# Altium schematic sheet Demo_BLDC_1000W_36V.SchDoc
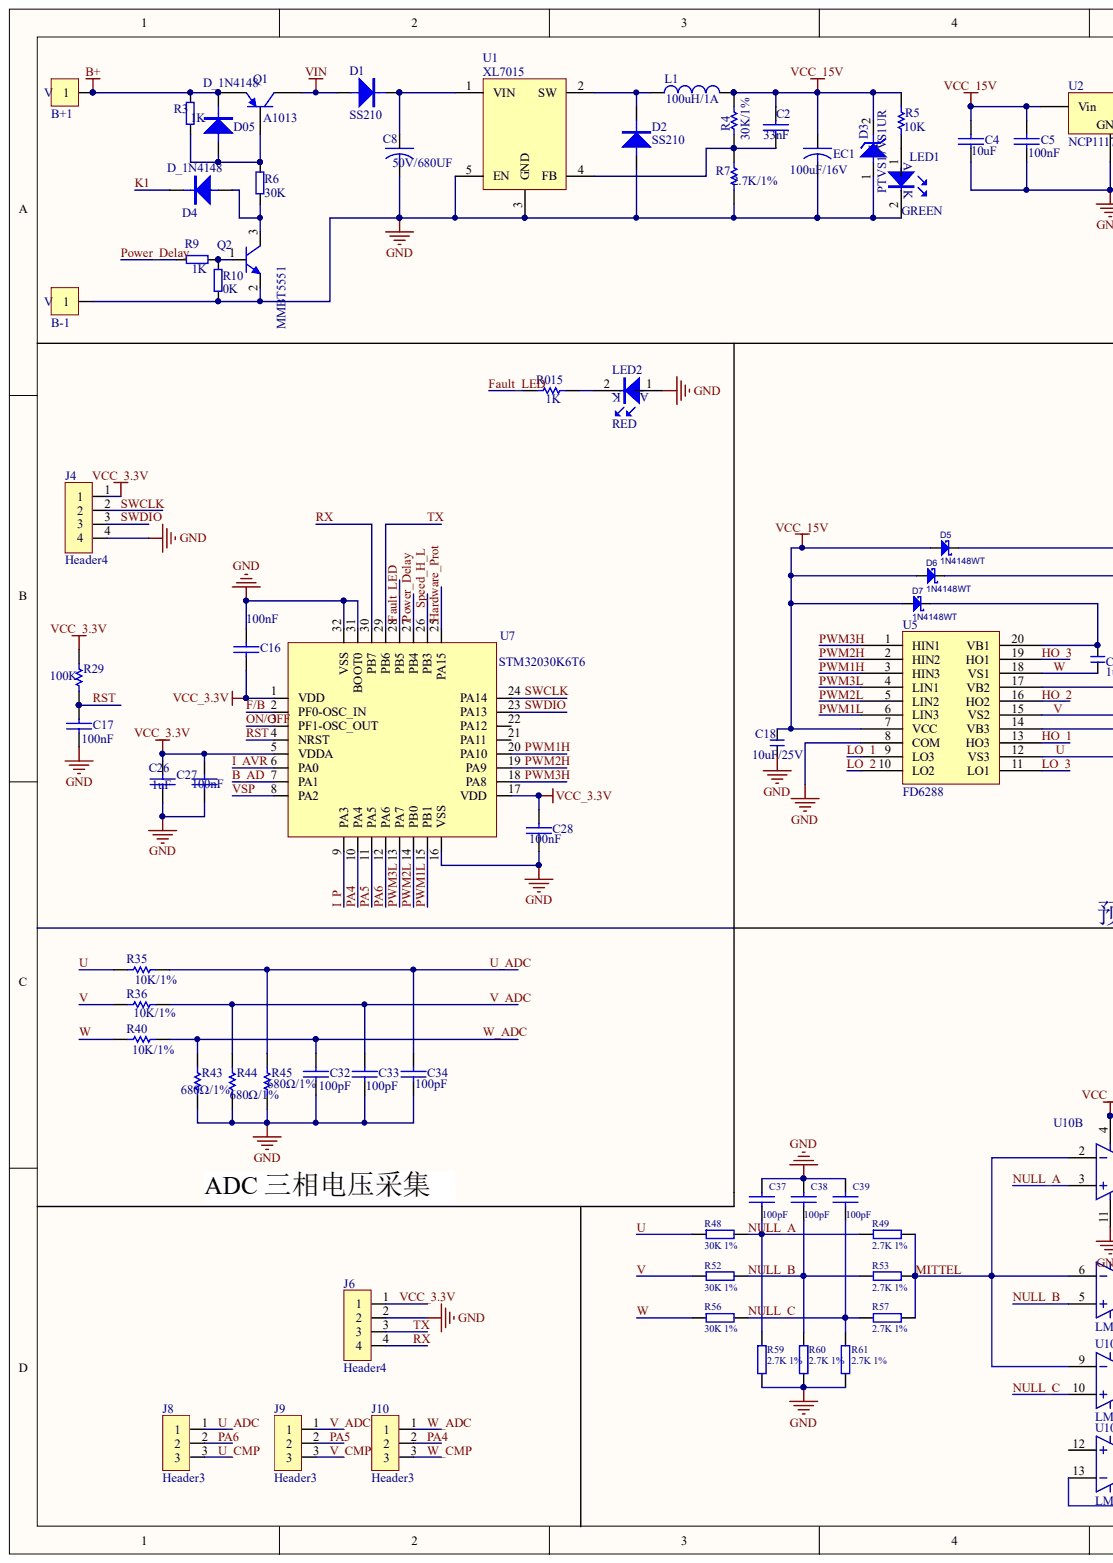
[diagram p0: Demo_BLDC_1000W_36V.SchDoc, left]
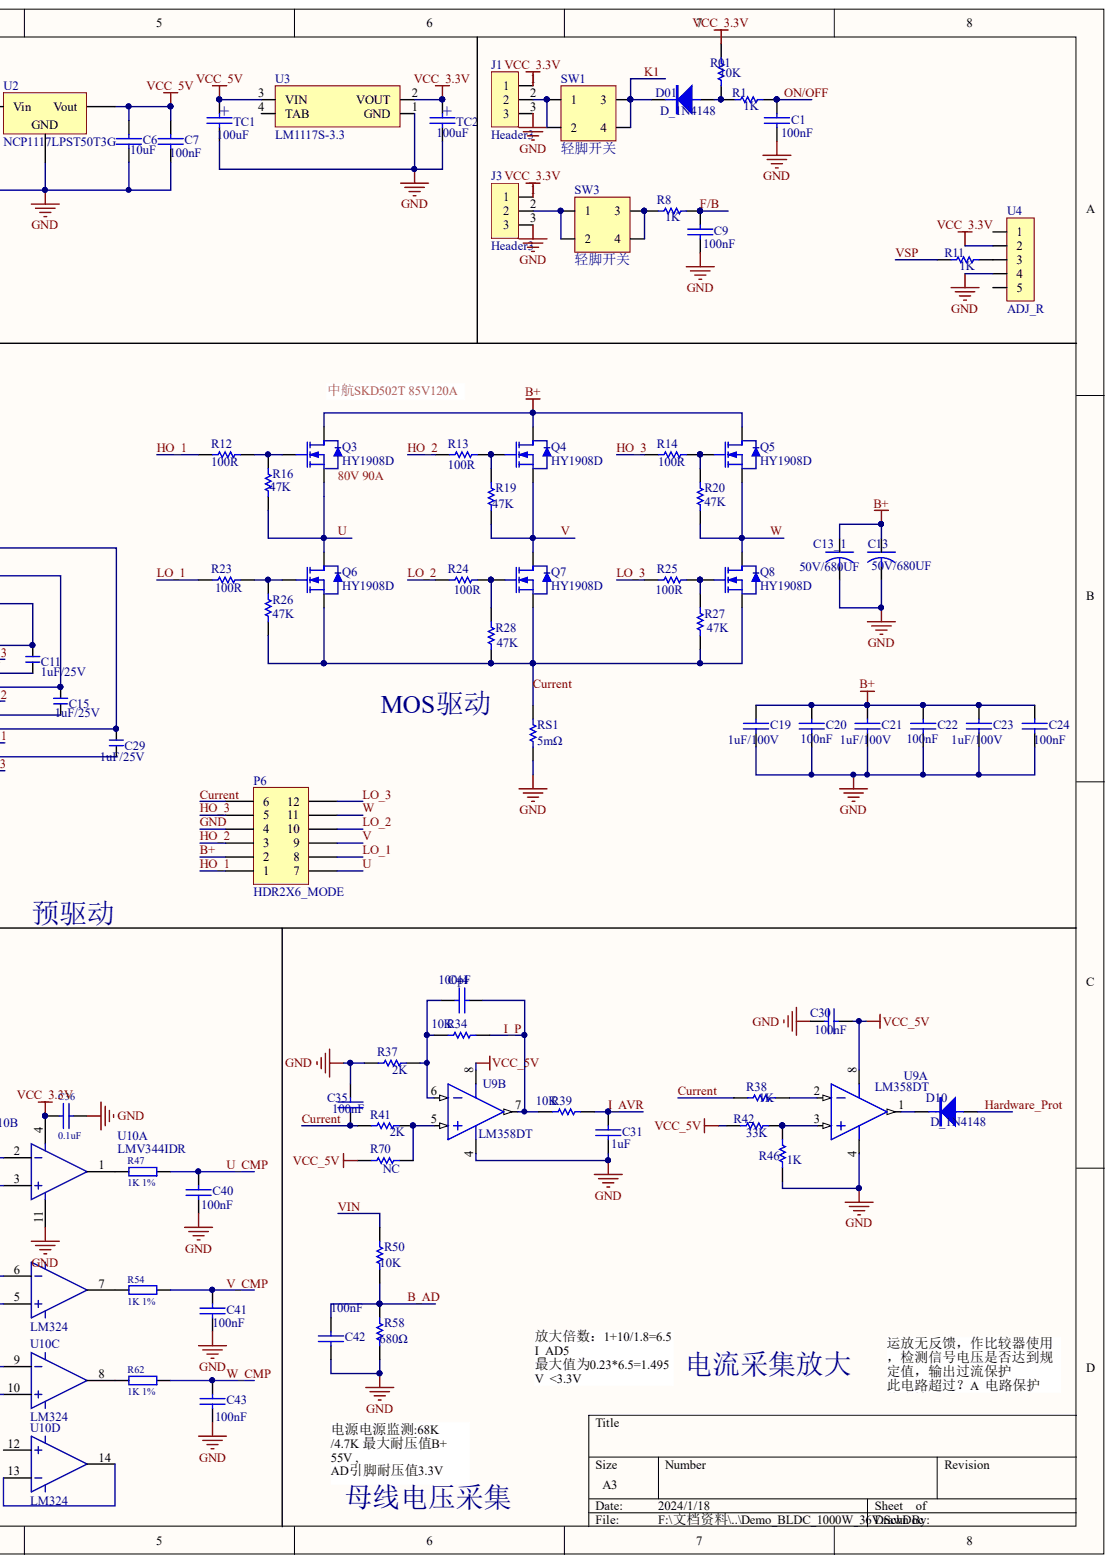
[diagram p1: Demo_BLDC_1000W_36V.SchDoc, right]

## Parts
B+1  V  [TP]
B-1  V  [TP]
C1  100nF  [C0603]
C2  33nF  [C0603]
C4  10uF  [C0805]
C5  100nF  [C0603]
C6  10uF  [C0805]
C7  100nF  [C0603]
C8  50V/680UF  [CAP-SMD_BD6.3-L6.6-W6.6-FD]
C9  100nF  [C0603]
C11  1uF/25V  [0603_R]
C13  50V/680UF  [CAP_12.5*20MM]
C13_1  50V/680UF  [CAP_12.5*20MM]
C15  1uF/25V  [0603_R]
C16  100nF  [C0603]
C17  100nF  [C0603]
C18  10uF/25V  [0603_C]
C19  1uF/100V  [C0805]
C20  100nF  [C0805]
C21  1uF/100V  [C0805]
C22  100nF  [C0805]
C23  1uF/100V  [C0805]
C24  100nF  [C0805]
C26  1uF  [C0603]
C27  100nF  [C0603]
C28  100nF  [C0603]
C29  1uF/25V  [0603_R]
C30  100nF  [C0603]
C31  1uF  [C0603]
C32  100pF  [C0603]
C33  100pF  [C0603]
C34  100pF  [C0603]
C35  100nF  [C0603]
C36  0.1uF  [C0603]
C37  100pF  [C0603]
C38  100pF  [C0603]
C39  100pF  [C0603]
C40  100nF  [C0603]
C41  100nF  [C0603]
C42  100nF  [C0603]
C43  100nF  [C0603]
C44  100pF  [C0603]
D01  D_1N4148  [SOD_323]
D1  SS210  [SMA]
D2  SS210  [SMA]
D3  PTVS17VS1UR  [SOD-123]
D4  D_1N4148  [SOD_323]
D05  D_1N4148  [SOD-123]
D5  1N4148WT  [SOD-323]
D6  1N4148WT  [SOD-323]
D7  1N4148WT  [SOD-323]
D10  D_1N4148  [SOD_323]
EC1  100uF/16V  [CAP-SMD_BD6.3-L6.6-W6.6-FD]
J1  Header3  [Header_3]
J3  Header3  [Header_3]
J4  Header4  [Header_4 - duplicate]
J6  Header4  [Header_4]
J8  Header3  [Header_3]
J9  Header3  [Header_3]
J10  Header3  [Header_3]
L1  100uH/1A  [L_SMD5.8*5.2*4.5MM]
LED1  GREEN  [LED-0805]
LED2  RED  [LED-0805]
P6  HDR2X6_MODE  [HDR2X6_MODE]
Q1  A1013  [SOT-89]
Q2  MMBT5551  [SOT-23]
Q3  HY1908D  [TO-220-3L]
Q4  HY1908D  [TO-220-3L]
Q5  HY1908D  [TO-220-3L]
Q6  HY1908D  [TO-220-3L]
Q7  HY1908D  [TO-220-3L]
Q8  HY1908D  [TO-220-3L]
R01  10K  [R0603]
R1  1K  [R0603]
R3  1K  [R0603]
R4  30K/1%  [R0603]
R5  10K  [R0805]
R6  30K  [R0603]
R7  2.7K/1%  [R0603]
R8  1K  [R0603]
R9  1K  [R0603]
R10  0K  [R0603]
R11  1K  [R0603]
R12  100R  [R0603]
R13  100R  [R0603]
R14  100R  [R0603]
R015  1K  [R0603]
R16  47K  [R0603]
R19  47K  [R0603]
R20  47K  [R0603]
R23  100R  [R0603]
R24  100R  [R0603]
R25  100R  [R0603]
R26  47K  [R0603]
R27  47K  [R0603]
R28  47K  [R0603]
R29  100K  [R0603]
R34  10K  [R0603]
R35  10K/1%  [R0603]
R36  10K/1%  [R0603]
R37  2K  [R0603]
R38  1K  [R0603]
R39  10K  [R0603]
R40  10K/1%  [R0603]
R41  2K  [R0603]
R42  33K  [R0603]
R43  680Ω/1%  [R0603]
R44  680Ω/1%  [R0603]
R45  680Ω/1%  [R0603]
R46  1K  [R0603]
R47  1K 1%  [R0603]
R48  30K 1%  [R0603]
R49  2.7K 1%  [R0603]
R50  10K  [R0603]
R52  30K 1%  [R0603]
R53  2.7K 1%  [R0603]
R54  1K 1%  [R0603]
R56  30K 1%  [R0603]
R57  2.7K 1%  [R0603]
R58  680Ω  [R0603]
R59  2.7K 1%  [R0603]
R60  2.7K 1%  [R0603]
R61  2.7K 1%  [R0603]
R62  1K 1%  [R0603]
R70  NC  [R0603]
RS1  5mΩ  [R2512]
SW1  轻脚开关  [轻脚开关small]
SW3  轻脚开关  [轻脚开关small]
TC1  100uF  [TC_3528]
TC2  100uF  [TC_3528]
U1  XL7015  [TO-252-5]
U2  NCP1117LPST50T3G  [SOT-223]
U3  LM1117S-3.3  [SOT_223]
U4  ADJ_R  [RV09]
U5  FD6288  [TSSOP-20_L6.5-W4.4-P0.65-LS6.4-BL]
U7  STM32030K6T6  [LQFP32]
U9  LM358DT  [SOIC8]  (parts A,B)
U10  LMV344IDR  [sop14]  (parts A,B,C,D)

## Nets
VCC_5V: C6.1 C7.1 C30.2 R42.1 R70.1 TC1.1 U2.2 U3.3(VIN) U9.8
VCC_15V: C2.1 C4.1 C5.1 C18.1 D3.2 D5.1 D6.1 D7.1 EC1.1 L1.2 R4.2 R5.2 U2.3 U5.7(VCC)
B+: B+1.1 C13.1 C13_1.1 C19.1 C20.1 C21.1 C22.1 C23.1 C24.1 D05.2 P6.2 Q1.3 Q3.2 Q4.2 Q5.2 R3.2
B_AD: C42.1 R50.1 R58.2 U7.7(PA1)
CURRENT: C35.2 P6.6 Q6.3 Q7.3 Q8.3 R26.1 R27.1 R28.1 R38.1 R41.1 RS1.2
F/B: C9.1 R8.2 U7.2(PF0-OSC_IN)
FAULT_LED: R015.2 U7.28(PB5)
GND: B-1.1 C1.2 C4.2 C5.2 C6.2 C7.2 C8.2 C9.2 C13.2 C13_1.2 C16.1 C17.2 C18.2 C19.2 C20.2 C21.2 C22.2 C23.2 C24.2 C26.2 C27.2 C28.2 C30.1 C31.2 C32.2 C33.2 C34.2 C35.1 C36.1 C37.2 C38.2 C39.2 C40.2 C41.2 C42.2 C43.2 D2.1 D3.1 EC1.2 J1.3 J3.3 J4.4 J6.2 LED1.2 LED2.1 P6.4 Q2.2 R7.1 R10.2 R37.1 R43.2 R44.2 R45.2 R46.1 R58.1 R59.1 R60.1 R61.1 RS1.1 TC1.2 TC2.2 U1.3(GND) U1.5(EN) U2.1 U3.1(GND) U4.4 U5.8(COM) U7.16(VSS) U7.31(BOOT0) U7.32(VSS) U9.4 U10.11
HARDWARE_PROT: D10.1 U7.25(PA15)
HO_1: P6.1 R12.1 U5.13(HO3)
HO_2: P6.3 R13.1 U5.16(HO2)
HO_3: P6.5 R14.1 U5.19(HO1)
I_AVR: C31.1 R39.2 U7.6(PA0)
I_P: C44.2 R34.2 R39.1 U7.9(PA3) U9.7
K1: D01.2 D4.2 SW1.3 SW1.4
LO_1: P6.8 R23.1 U5.9(LO3)
LO_2: P6.10 R24.1 U5.10(LO2)
LO_3: P6.12 R25.1 U5.11(LO1)
MITTEL: R49.2 R53.2 R57.2 U10.2 U10.6 U10.9
NULL_A: C37.1 R48.2 R49.1 R59.2 U10.3
NULL_B: C38.1 R52.2 R53.1 R60.2 U10.5
NULL_C: C39.1 R56.2 R57.1 R61.2 U10.10
ON/OFF: C1.1 R1.2 U7.3(PF1-OSC_OUT)
PA4: J10.2 U7.10(PA4)
PA5: J9.2 U7.11(PA5)
PA6: J8.2 U7.12(PA6)
POWER_DELAY: R9.2 U7.27(PB4)
PWM1H: U5.3(HIN3) U7.20(PA10)
PWM1L: U5.6(LIN3) U7.15(PB1)
PWM2H: U5.2(HIN2) U7.19(PA9)
PWM2L: U5.5(LIN2) U7.14(PB0)
PWM3H: U5.1(HIN1) U7.18(PA8)
PWM3L: U5.4(LIN1) U7.13(PA7)
RST: C17.1 R29.2 U7.4(NRST)
RX: J6.4 U7.30(PB7)
SPEED_H_L: U7.26(PB3)
SWCLK: J4.2 U7.24(PA14)
SWDIO: J4.3 U7.23(PA13)
TX: J6.3 U7.29(PB6)
U: C29.2 P6.7 Q3.3 Q6.2 R16.1 R35.2 R48.1 U5.12(VS3)
U_ADC: C34.1 J8.1 R35.1 R45.1
U_CMP: C40.1 J8.3 R47.1
V: C15.2 P6.9 Q4.3 Q7.2 R19.1 R36.2 R52.1 U5.15(VS2)
V_ADC: C33.1 J9.1 R36.1 R44.1
V_CMP: C41.1 J9.3 R54.1
VCC_3.3V: C16.2 C26.1 C27.1 C28.1 C36.2 J1.1 J3.1 J4.1 J6.1 R01.2 R29.1 TC2.1 U3.2(VOUT) U4.2 U7.1(VDD) U7.5(VDDA) U7.17(VDD) U10.4
VIN: D1.1 Q1.2 R50.2
VSP: R11.1 U7.8(PA2)
W: C11.2 P6.11 Q5.3 Q8.2 R20.1 R40.2 R56.1 U5.18(VS1)
W_ADC: C32.1 J10.1 R40.1 R43.1
W_CMP: C43.1 J10.3 R62.1
NetC2_2: C2.2 R4.1 R7.2 U1.4(FB)
NetC8_1: C8.1 D1.2 U1.1(VIN)
NetC11_1: C11.1 D7.2 U5.20(VB1)
NetC15_1: C15.1 D6.2 U5.17(VB2)
NetC29_1: C29.1 D5.2 U5.14(VB3)
NetC44_1: C44.1 R34.1 R37.2 U9.6
NetD01_1: D01.1 R01.1 R1.1
NetD2_2: D2.2 L1.1 U1.2(SW)
NetD4_1: D4.1 Q2.3 R6.1
NetD05_1: D05.1 Q1.1 R3.1 R6.2
NetD10_2: D10.2 U9.1
NetJ1_2: J1.2 SW1.1 SW1.2
NetJ3_2: J3.2 SW3.1 SW3.2
NetLED1_1: LED1.1 R5.1
NetLED2_2: LED2.2 R015.1
NetQ2_1: Q2.1 R9.1 R10.1
NetQ3_1: Q3.1 R12.2 R16.2
NetQ4_1: Q4.1 R13.2 R19.2
NetQ5_1: Q5.1 R14.2 R20.2
NetQ6_1: Q6.1 R23.2 R26.2
NetQ7_1: Q7.1 R24.2 R28.2
NetQ8_1: Q8.1 R25.2 R27.2
NetR8_1: R8.1 SW3.3 SW3.4
NetR11_2: R11.2 U4.3
NetR38_2: R38.2 U9.2
NetR41_2: R41.2 R70.2 U9.5
NetR42_2: R42.2 R46.2 U9.3
NetR47_2: R47.2 U10.1
NetR54_2: R54.2 U10.7
NetR62_2: R62.2 U10.8
NetU10_13: U10.13 U10.14
N00018:

Not connected: U3 4(TAB); U4 1 5; U7 21(PA11) 22(PA12); U10 12

## Library data of the parts
B+1 B-1 = VIA: Component Kind: Standard; Library Name: BLDC.SchLib
C1 C5 C7 C9 C16 C17 C20 C22 C24 C27 C28 C30 C35 C40-C44 = Cap_104K: 贴片电容     精度  ±10%     容值  100nF     额定电压  50V     温漂系数（介质材料)  X7R; Name: 贴片电容/ 100nF(104) ±10% 50V; 品牌: SAMSUNG三星; 型号: CL10B333KB8NNNC; Component Kind: Standard; Library Name: BLDC.SchLib; Tolerance: 10%; 立创编号: C21117
C2 = Cap_333K: 精度: 1%; Component Kind: Standard; Library Name: BLDC.SchLib
C4 C6 C26 C31 = Cap_106K: 贴片电容     精度  ±10%     容值  10uF     额定电压  50V     温漂系数（介质材料)  X7R; Name: 贴片电容/ 10uF(106) ±10% 50V; 厂家: SAMSUNG三星; 型号: CL31B106KBHNNNE; Component Kind: Standard; Library Name: BLDC.SchLib; Tolerance: 10%; 立创编号: C89632
C8 C13 C13_1 = 50V/680UF: 直插电解电容; Component Kind: Standard; Library Name: BLDC.SchLib; Value: 50V/680UF
C11 C15 = C0805-1uF/25V: X5R,20%; Mfr P/N: C2012X5R1E105K; Value: 1uF,25V, X5R; Component Kind: Standard; Library Name: cap_n.SchLib
C18 C29 = C0805-1uF/25V: X5R,20%; Mfr P/N: C2012X5R1E105K; Component Kind: Standard; Library Name: cap_n.SchLib
C19 C21 C23 = 100nF/100V 直插独石电容: 直插独石电容    容值100nf  额定电压100V  精度10% 间距5.08mm; Tolerance: 10%; 品牌: TORCH火炬; 型号: CT4G-1206-2X1-100V-0.1UF-K; Component Kind: Standard; Library Name: BLDC.SchLib; Name: 直插独石电容
C32 = Cap_104K: 贴片电容     精度  ±10%     容值  100pF    额定电压  50V     温漂系数（介质材料)  X7R; Name: 贴片电容/ 100pF±10% 50V; 品牌: SAMSUNG三星; Component Kind: Standard; Library Name: BLDC.SchLib; Tolerance: 10%; Value: 100pF
C33 = Cap_104K: 贴片电容     精度  ±10%     容值  100pF    额定电压  50V     温漂系数（介质材料)  X7R; Name: 贴片电容/ 100nF(104) ±10% 50V; 品牌: SAMSUNG三星; 型号: CL10B333KB8NNNC; Component Kind: Standard; Library Name: BLDC.SchLib; Tolerance: 10%; Value: 100pF; 立创编号: C21117
C34 = Cap_104K: 贴片电容     精度  ±10%     容值 100pF    额定电压  50V     温漂系数（介质材料)  X7R; Name: 贴片电容/ 100nF(104) ±10% 50V; 品牌: SAMSUNG三星; 型号: CL10B333KB8NNNC; Component Kind: Standard; Library Name: BLDC.SchLib; Tolerance: 10%; Value: 100pF; 立创编号: C21117
C36-C39 = CAP: Capacitor; Component Kind: Standard; Library Name: schematic.SchLib
D01 D4 D05 D10 = D_1N4148: 开关二极管 反向恢复时间  4ns    反向耐压VR  71V     平均整流电流IF  150mA     正向压降VF  1V @ 50mA; 厂家: CJ江苏长电; 型号: 1N4148WS; Component Kind: Standard; Library Name: BLDC.SchLib; Name: 开关二极管; 立创编号: C118873
D1 D2 = D_SS210: 肖特基二极管   直流反向耐压100V  平均整流电流2A  正向压降850mv@2A; 品牌: Slkor萨科微; Component Kind: Standard; Library Name: BLDC.SchLib; Name: 肖特基二极管
D3 = TVS1: Zener Diode; Component Kind: Standard
D5-D7 = 1N4148WT: SURFACE MOUNT FAST SWITCHING DIODE; Mfr: DIODES; TOPMARK: T4; Component Kind: Standard; Library Name: Diode_STD.SchLib
EC1 = ECap_100UF: 贴片型铝电解电容     容值  100uF     精度  ±20%     额定电压  16V         外观尺寸(￠DxL,mm)  6.3x5.3     工作温度  -45℃ ~ +105℃; Name: 贴片电解电容; 厂家: lelon(台湾立隆); 型号: VES101M1CTR-0605; Component Kind: Standard; Library Name: BLDC.SchLib; Tolerance: 20%; Value: 100UF/16V
J1 J3 J8-J10 = Header_3: Component Kind: Standard; Library Name: BLDC.SchLib
J4 J6 = Header_4: Component Kind: Standard; Library Name: BLDC.SchLib
L1 = IN6_47uH: 贴片电感     精度  ±20%     额定电流  1.5A     直流电阻（内阻）  180mΩ     电感值  47uH; Name: 贴片电感/ 47uH ±20% 1.5A; 型号: CMI321611J470KT; Component Kind: Standard; Library Name: BLDC.SchLib
LED1 LED2 = LED: Component Kind: Standard
P6 = Header 6X2A: Header, 6-Pin, Dual row; Component Kind: Standard; LatestRevisionDate: 17-Jul-2002; LatestRevisionNote: Re-released for DXP Platform.; Library Name: Miscellaneous Connectors.IntLib; Publisher: Altium Limited
Q1 = SOT-89 B772 160-320: 三极管; Component Kind: Standard; ComponentLink1Description: 供应商链接; ComponentLink1URL: http://www.szlcsc.com/product/details_25018.html; Library Name: Transistor_PNP.SchLib; Package: SOT-89; Supplier: LC; SuppliersPartNumber: C24278
Q2 = NPN/SOT-23: NPN Bipolar Transistor; Code_JEDEC: TO-226-AA; Component Kind: Standard; LatestRevisionDate: 17-Jul-2002; LatestRevisionNote: Re-released for DXP Platform.; PackageDescription: TO, Flat Index; 3 In-Line, Axial Leads; Body Dia. 4.8mm; Leads 0.55 x 0.51 mm (max); PackageReference: TO-226-AA; Published: 8-Jun-2000; Library Name: zenchant.SchLib; Publisher: Altium Limited
Q3-Q8 = HY1908D: NMOS  80V/90A ，最大功耗64W (T=25℃); 品牌: HUAYI华羿微; Component Kind: Standard; Library Name: BLDC.SchLib
R01 R1 R8 R11 = Res_102J: 贴片电阻  功率0.1W精度±5%阻值（欧姆）1kΩ  温度系数±100 ppm/℃; Name: 贴片电阻/1KΩ(102) ±5%; Tolerance: 5%; 厂家: YAGEO国巨; 型号: RC0603JR-071KL; Component Kind: Standard; Library Name: BLDC.SchLib; 立创编号: C14676
R3 R6 R9 R10 = Res1: 电阻; Part Number: 1T00R0045; Component Kind: Standard; ComponentLink1Description: 2K2,0603,5%; Component Type: Standard; Designator: 1T00R0045; LatestRevisionDate: 17-Jul-2002; LatestRevisionNote: Re-released for DXP Platform.; PackageReference: AXIAL-0.4; Published: 8-Jun-2000; Publisher: Altium Limited
R4 = Res_303J: 精度: 1%; Component Kind: Standard; Library Name: BLDC.SchLib
R5 R015 R34 R70 = Res_103J: 贴片电阻     阻值（欧姆）  10K     精度  ±5%     功率  1/10W     温度系数  ±100ppm/℃; Name: 贴片电阻/ 10KΩ(103) ±5%; 厂家: YAGOO; 型号: RC0603JR-0710KL; Component Kind: Standard; Library Name: BLDC.SchLib; Tolerance: 5%; 立创编号: C99198
R7 = Res_272J: Value: 2.7K; 精度: 1%; Component Kind: Standard; Library Name: BLDC.SchLib
R12-R14 R23-R25 = Res_101J: 贴片电阻     阻值（欧姆）  100     精度  ±5%     功率  1/10W     温度系数  ±100ppm/℃; Name: 贴片电阻/100Ω(101) ±5%; 厂家: YAGEO国巨; 型号: RC0603JR-07100RL; Component Kind: Standard; Library Name: BLDC.SchLib; Tolerance: 5%; 立创编号: C110091
R16 R19 R20 R26-R28 R58 = Res_472J: 贴片电阻     阻值（欧姆）  4.7K     精度  ±5%     功率  1/10W     温度系数  ±100ppm/℃; Name: 贴片电阻/ 4.7KΩ(472) ±5%; U8料号: Y110146; 厂家: YAGOO; 型号: RC0603JR-074K7L; Component Kind: Standard; Library Name: BLDC.SchLib; Tolerance: 5%; 立创编号: C105428
R29 = Res_104J: 贴片电阻 100K  精度5%; Tolerance: 5%; Component Kind: Standard; Library Name: BLDC.SchLib; Name: 贴片电阻
R35 R36 R40 = Res3_103J: 贴片电阻     阻值（欧姆）  10K     精度  ±5%     功率  1/10W     温度系数  ±100ppm/℃; Name: 贴片电阻/ 10KΩ(103) ±5%; Tolerance: 1%; 厂家: YAGOO; 型号: RC0603JR-0710KL; Component Kind: Standard; Library Name: BLDC.SchLib; 立创编号: C99198
  R36 R40: Value: 10k
R37 = Res_182J: Value: 1.8K; Component Kind: Standard; Library Name: BLDC.SchLib
R38 R39 R41 = Res_511J: 贴片电阻     阻值（欧姆）  510     精度  ±5%     功率  0.1W     温度系数  ±100ppm/°C; Name: 贴片电阻/510Ω(511 ±5%; 厂家: YAGEO国巨; 型号: RC0603JR-07510RL; Component Kind: Standard; Library Name: BLDC.SchLib; Tolerance: 5%; 立创编号: C113299
R42 = Res_333J: Component Kind: Standard; Library Name: BLDC.SchLib
R43 = Res_681J: 贴片电阻    阻值680Ω   精度±1%  功率0.1w; Value: 680Ω; 品牌: YAGEO国巨; 型号: RC0603FR-07680RL; Component Kind: Standard; Library Name: BLDC.SchLib; Name: 贴片电阻; 立创编号: C112310
R44 R45 = Res_681J: 贴片电阻    阻值680Ω   精度±1%  功率0.1w; Value: 680Ω; 品牌: YAGEO国巨; 型号: RC0603FR-07680RL; 精度: 1%; Component Kind: Standard; Library Name: BLDC.SchLib; Name: 贴片电阻; 立创编号: C112310
R46 = Res_162J: Component Kind: Standard; Library Name: BLDC.SchLib
R47-R49 R52-R54 R56 R57 R59-R62 = RES: Component Kind: Standard; Library Name: schematic.SchLib
R50 = Res_683J: 贴片电阻     阻值（欧姆）  68K     精度  ±1%     功率  0.1W     温度系数  ±100ppm/°C; Name: 贴片电阻/ 68KΩ(683) ±5%; Tolerance: 5%; 厂家: YAGEO国巨; 型号: AC0402JR-0768KL; Component Kind: Standard; Library Name: BLDC.SchLib; 立创编号: C114633
RS1 = Res_10mΩ_2512: 贴片低阻值采样电阻     阻值（欧姆）  0.005   精度  ±1%     安装类型  表面贴装型     功率  2W; Name: 贴片低阻值采样电阻/0.01Ω(R010) ±1%; 厂家: PSA信昌电陶; 型号: FMF25FPJR010-LH; Component Kind: Standard; Library Name: BLDC.SchLib; Tolerance: 1%; 立创编号: C105366
TC1 TC2 = TCap28_107K: 钽电容     精度  ±20%     容值  100uF     额定电压  10V     ESR串联电阻  1.4Ω@ 100kHz     工作温度  -55℃ ~ +125℃; Name: 钽电容/ 100uF(1067 ±20% 10V; 厂家: AVX; 型号: TAJB107M010RNJ; Component Kind: Standard; Library Name: BLDC.SchLib; Tolerance: 20%; Value: 100uF; 立创编号: C7196
U2 = SOT-223 AMS1117-5.0: |ì¨a?12???D??¨¨?1(LDO); Component Kind: Standard; ComponentLink1Description: 1?¨?|¨; ComponentLink1URL: http://www.szlcsc.com/product/details_6653.html; Library Name: Miscellaneous Devices LC.IntLib; Package: SOT-223; Supplier: LC; SuppliersPartNumber: C6187
U3 = IC_LM1117S-3.3: LDO 固定输出电压3.3V   最大输入电压20V ，最大输出电流1A; Component Kind: Standard; Library Name: BLDC.SchLib
U4 = RV09: RV09型电位器 10K; Component Kind: Standard; Library Name: Schlib1.SchLib
U7 = IC_STM32F030K6T6: 32-Bit  MCU /工作电压 2.4V~3.6V /主频最大48M; Component Kind: Standard; Library Name: BLDC.SchLib
U9 = LM358: 通用运放  2组放大    GBP1.1MHZ    工作温度 0-70℃  电压范围 3-32V; Component Kind: Standard; Library Name: BLDC.SchLib
U10 = LM324: Component Kind: Standard; Library Name: schematic.SchLib
SW1 SW3 = 轻脚开关: Component Kind: Standard; Library Name: Schlib1.SchLib
U1 = XL7015: Component Kind: Standard; Description: XL7015
U5 = FD6288: Component Kind: Standard; Library Name: Schlib1.SchLib
